# Altium schematic sheet AD-SDIO_2_Click_v101.SchDoc(AD-SDIO_2_Click_v101)
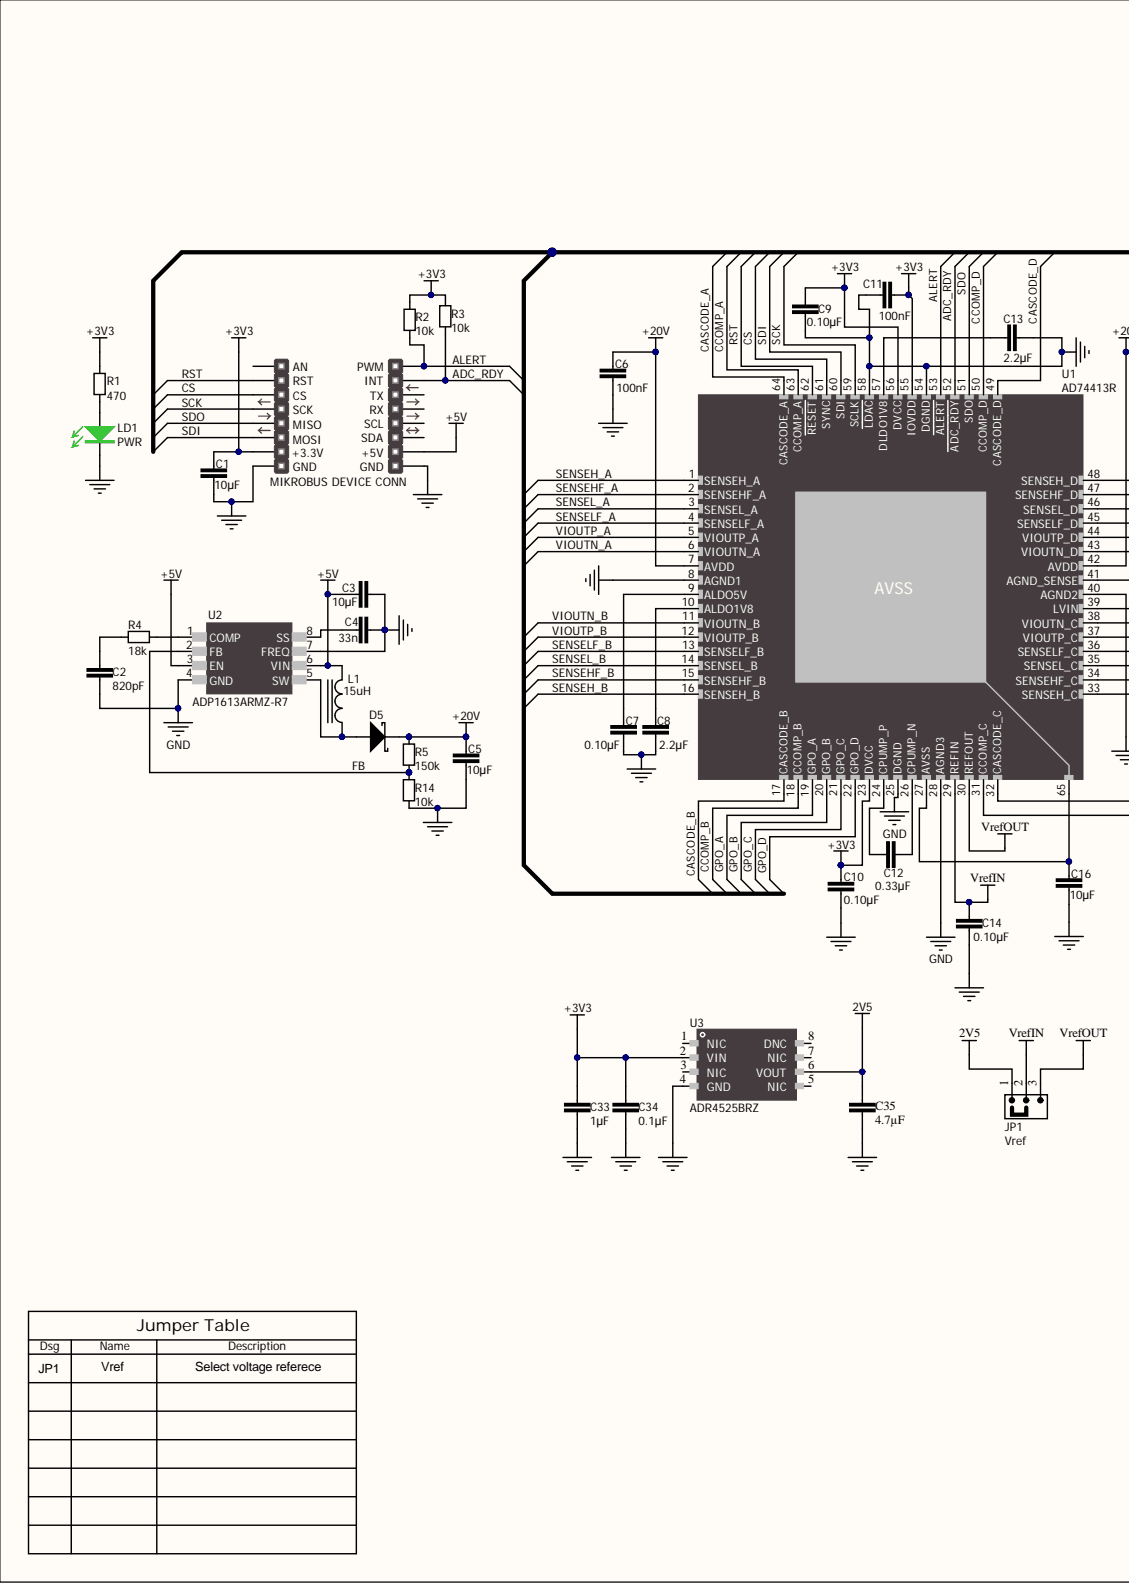
[diagram p0: AD-SDIO_2_Click_v101.SchDoc, left]
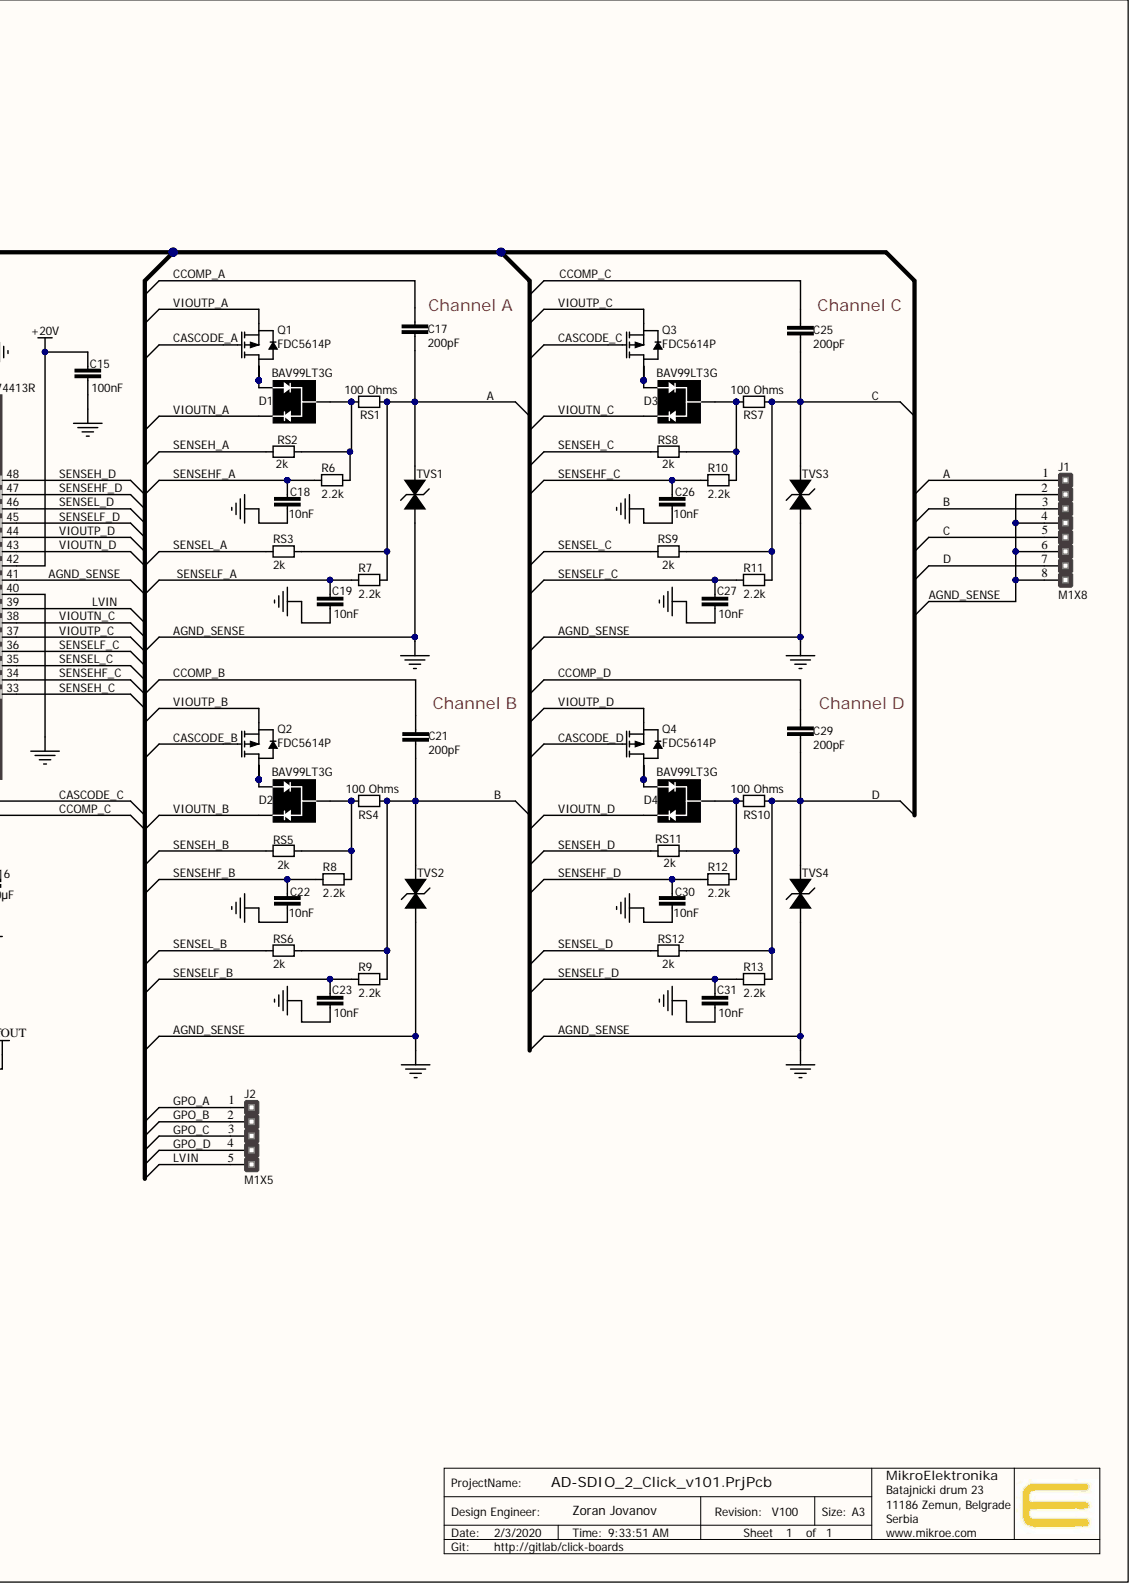
[diagram p1: AD-SDIO_2_Click_v101.SchDoc, right]

## Parts
C1  10µF  [CAPC2013X100N-0805-NoOverlay]
C2  820pF  [CAPC2013X100N-0805-NoOverlay]
C3  10µF  [CAPC2013X100N-0805-NoOverlay]
C4  33n  [CAPC1608X55N-0603-NoOverlay]
C5  10µF  [CAPC2013X100N-0805-NoOverlay]
C6  100nF  [CAPC1608X55N-0603-NoOverlay]
C7  0.10µF  [CAPC1608X55N-0603-NoOverlay]
C8  2.2µF  [CAPC1608X55N-0603-NoOverlay]
C9  0.10µF  [CAPC1608X55N-0603-NoOverlay]
C10  0.10µF  [CAPC1608X55N-0603-NoOverlay]
C11  100nF  [CAPC1608X55N-0603-NoOverlay]
C12  0.33µF  [CAPC2013X100N-0805-NoOverlay]
C13  2.2µF  [CAPC1608X55N-0603-NoOverlay]
C14  0.10µF  [CAPC1608X55N-0603-NoOverlay]
C15  100nF  [CAPC1608X55N-0603-NoOverlay]
C16  10µF  [CAPC2013X100N-0805-NoOverlay]
C17  200pF  [CAPC1608X55N-0603-NoOverlay]
C18  10nF  [CAPC1608X55N-0603-NoOverlay]
C19  10nF  [CAPC1608X55N-0603-NoOverlay]
C21  200pF  [CAPC1608X55N-0603-NoOverlay]
C22  10nF  [CAPC1608X55N-0603-NoOverlay]
C23  10nF  [CAPC1608X55N-0603-NoOverlay]
C25  200pF  [CAPC1608X55N-0603-NoOverlay]
C26  10nF  [CAPC1608X55N-0603-NoOverlay]
C27  10nF  [CAPC1608X55N-0603-NoOverlay]
C29  200pF  [CAPC1608X55N-0603-NoOverlay]
C30  10nF  [CAPC1608X55N-0603-NoOverlay]
C31  10nF  [CAPC1608X55N-0603-NoOverlay]
C33  1µF  [CAPC1005X55N-0402-NoOverlay]
C34  0.1µF  [CAPC1005X55N-0402-NoOverlay]
C35  4.7µF  [CAPC1005X55N-0402-NoOverlay]
D1  BAV99LT3G  [SOT95P240X110-3N-NoOverlay]
D2  BAV99LT3G  [SOT95P240X110-3N-NoOverlay]
D3  BAV99LT3G  [SOT95P240X110-3N-NoOverlay]
D4  BAV99LT3G  [SOT95P240X110-3N-NoOverlay]
D5  MBRA340T3G  [DIODFN430X400X230-2N]  (value not drawn)
J1  M1X8  [HM1x8-NoOverlay]
J2  M1X5  [HM1X5-NoOverlay]
JP1  Vref  [JUMPER-0805-V2-12]
L1  15uH  [XAL4040-NOOVERLAY]
LD1  PWR  [DIOGREENC2013X120N-0805-NoOverlay]
MBD1  MIKROBUS DEVICE CONN  [New Click Template_LARGE-SG]  (no BOM)
Q1  FDC5614P  [TSOT-23-6-NoOverlay]
Q2  FDC5614P  [TSOT-23-6-NoOverlay]
Q3  FDC5614P  [TSOT-23-6-NoOverlay]
Q4  FDC5614P  [TSOT-23-6-NoOverlay]
R1  470  [RESC2013X38N-0805-NOOVERLAY]
R2  10k  [RESC2013X38N-0805-NOOVERLAY]
R3  10k  [RESC2013X38N-0805-NOOVERLAY]
R4  18k  [RESC1508X55N-0603-NOOVERLAY]
R5  150k  [RESC1508X55N-0603-NOOVERLAY]
R6  2.2k  [RESC1508X55N-0603-NOOVERLAY]
R7  2.2k  [RESC1508X55N-0603-NOOVERLAY]
R8  2.2k  [RESC1508X55N-0603-NOOVERLAY]
R9  2.2k  [RESC1508X55N-0603-NOOVERLAY]
R10  2.2k  [RESC1508X55N-0603-NOOVERLAY]
R11  2.2k  [RESC1508X55N-0603-NOOVERLAY]
R12  2.2k  [RESC1508X55N-0603-NOOVERLAY]
R13  2.2k  [RESC1508X55N-0603-NOOVERLAY]
R14  10k  [RESC1508X55N-0603-NOOVERLAY]
RS1  100 Ohms  [RESC1508X55N-0603-NOOVERLAY]
RS2  2k  [RESC1508X55N-0603-NOOVERLAY]
RS3  2k  [RESC1508X55N-0603-NOOVERLAY]
RS4  100 Ohms  [RESC1508X55N-0603-NOOVERLAY]
RS5  2k  [RESC1508X55N-0603-NOOVERLAY]
RS6  2k  [RESC1508X55N-0603-NOOVERLAY]
RS7  100 Ohms  [RESC1508X55N-0603-NOOVERLAY]
RS8  2k  [RESC1508X55N-0603-NOOVERLAY]
RS9  2k  [RESC1508X55N-0603-NOOVERLAY]
RS10  100 Ohms  [RESC1508X55N-0603-NOOVERLAY]
RS11  2k  [RESC1508X55N-0603-NOOVERLAY]
RS12  2k  [RESC1508X55N-0603-NOOVERLAY]
TVS1  SMCJ40CA-TR  [DIOM7959X265N-NoOverlay]  (value not drawn)
TVS2  SMCJ40CA-TR  [DIOM7959X265N-NoOverlay]  (value not drawn)
TVS3  SMCJ40CA-TR  [DIOM7959X265N-NoOverlay]  (value not drawn)
TVS4  SMCJ40CA-TR  [DIOM7959X265N-NoOverlay]  (value not drawn)
U1  AD74413R  [QFN50P900X900X90-65T780X780N-QFN64-NoOverlay]
U2  ADP1613ARMZ-R7  [MSOP8P65_300X490X110L60X31N - NoOverlay]
U3  ADR4525BRZ  [SOIC127P600X175-8N-NoOverlay]

## Nets
2V5: C35.2 JP1.1 U3.6(VOUT)
+3V3: C1.2 C9.1 C10.1 C11.1 C33.2 C34.2 MBD1.7(+3.3V) R1.2 R2.2 R3.2 U1.23(DVCC) U1.55(IOVDD) U1.56(DVCC) U3.2(VIN)
+5V: C3.1 L1.1 MBD1.10(+5V) U2.3(EN) U2.6(VIN)
+20V: C5.2 C6.2 C15.2 D5.1 R5.2 U1.7(AVDD) U1.42(AVDD)
VrefIN: C14.2 JP1.2 U1.29(REFIN)
VrefOUT: JP1.3 U1.30(REFOUT)
A: C17.1 J1.1 R7.1 RS1.2 RS3.2 TVS1.2
ADC_RDY: MBD1.15(INT) R3.1 U1.52(ADC_RDY)
AGND_SENSE: C1.1 C2.1 C3.2 C4.2 C5.1 C6.1 C7.1 C8.1 C9.2 C10.2 C11.2 C13.2 C14.1 C15.1 C16.1 C18.1 C19.1 C22.1 C23.1 C26.1 C27.1 C30.1 C31.1 C33.1 C34.1 C35.1 J1.2 J1.4 J1.6 J1.8 LD1.1 MBD1.8(GND) MBD1.9(GND) R14.1 TVS1.1 TVS2.1 TVS3.1 TVS4.1 U1.8(AGND1) U1.25(DGND) U1.28(AGND3) U1.40(AGND2) U1.41(AGND_SENSE) U1.54(DGND) U1.58(LDAC) U2.4(GND) U2.7(FREQ) U3.4(GND)
ALERT: MBD1.16(PWM) R2.1 U1.53(ALERT)
B: C21.1 J1.3 R9.1 RS4.2 RS6.2 TVS2.2
C: C25.1 J1.5 R11.1 RS7.2 RS9.2 TVS3.2
CASCODE_A: Q1.3 U1.64(CASCODE_A)
CASCODE_B: Q2.3 U1.17(CASCODE_B)
CASCODE_C: Q3.3 U1.32(CASCODE_C)
CASCODE_D: Q4.3 U1.49(CASCODE_D)
CCOMP_A: C17.2 U1.63(CCOMP_A)
CCOMP_B: C21.2 U1.18(CCOMP_B)
CCOMP_C: C25.2 U1.31(CCOMP_C)
CCOMP_D: C29.2 U1.50(CCOMP_D)
CS: MBD1.3(CS) U1.61(SYNC)
D: C29.1 J1.7 R13.1 RS10.2 RS12.2 TVS4.2
FB: R5.1 R14.2 U2.2(FB)
GPO_A: J2.1 U1.19(GPO_A)
GPO_B: J2.2 U1.20(GPO_B)
GPO_C: J2.3 U1.21(GPO_C)
GPO_D: J2.4 U1.22(GPO_D)
LVIN: J2.5 U1.39(LVIN)
RST: MBD1.2(RST) U1.62(RESET)
SCK: MBD1.4(SCK) U1.59(SCLK)
SDI: MBD1.6(MOSI) U1.60(SDI)
SDO: MBD1.5(MISO) U1.51(SDO)
SENSEH_A: RS2.1 U1.1(SENSEH_A)
SENSEH_B: RS5.1 U1.16(SENSEH_B)
SENSEH_C: RS8.1 U1.33(SENSEH_C)
SENSEH_D: RS11.1 U1.48(SENSEH_D)
SENSEHF_A: C18.2 R6.2 U1.2(SENSEHF_A)
SENSEHF_B: C22.2 R8.2 U1.15(SENSEHF_B)
SENSEHF_C: C26.2 R10.2 U1.34(SENSEHF_C)
SENSEHF_D: C30.2 R12.2 U1.47(SENSEHF_D)
SENSEL_A: RS3.1 U1.3(SENSEL_A)
SENSEL_B: RS6.1 U1.14(SENSEL_B)
SENSEL_C: RS9.1 U1.35(SENSEL_C)
SENSEL_D: RS12.1 U1.46(SENSEL_D)
SENSELF_A: C19.2 R7.2 U1.4(SENSELF_A)
SENSELF_B: C23.2 R9.2 U1.13(SENSELF_B)
SENSELF_C: C27.2 R11.2 U1.36(SENSELF_C)
SENSELF_D: C31.2 R13.2 U1.45(SENSELF_D)
VIOUTN_A: D1.2 U1.6(VIOUTN_A)
VIOUTN_B: D2.2 U1.11(VIOUTN_B)
VIOUTN_C: D3.2 U1.38(VIOUTN_C)
VIOUTN_D: D4.2 U1.43(VIOUTN_D)
VIOUTP_A: Q1.4 U1.5(VIOUTP_A)
VIOUTP_B: Q2.4 U1.12(VIOUTP_B)
VIOUTP_C: Q3.4 U1.37(VIOUTP_C)
VIOUTP_D: Q4.4 U1.44(VIOUTP_D)
NetC2_2: C2.2 R4.1
NetC4_1: C4.1 U2.8(SS)
NetC7_2: C7.2 U1.9(ALDO5V)
NetC8_2: C8.2 U1.10(ALDO1V8)
NetC12_1: C12.1 U1.24(CPUMP_P)
NetC12_2: C12.2 U1.26(CPUMP_N)
NetC13_1: C13.1 U1.57(DLDO1V8)
NetC16_2: C16.2 U1.27(AVSS) U1.65
NetD1_1: D1.1 Q1.1 Q1.2 Q1.5 Q1.6
NetD1_3: D1.3 R6.1 RS1.1 RS2.2
NetD2_1: D2.1 Q2.1 Q2.2 Q2.5 Q2.6
NetD2_3: D2.3 R8.1 RS4.1 RS5.2
NetD3_1: D3.1 Q3.1 Q3.2 Q3.5 Q3.6
NetD3_3: D3.3 R10.1 RS7.1 RS8.2
NetD4_1: D4.1 Q4.1 Q4.2 Q4.5 Q4.6
NetD4_3: D4.3 R12.1 RS10.1 RS11.2
NetD5_2: D5.2 L1.2 U2.5(SW)
NetLD1_2: LD1.2 R1.1
NetR4_2: R4.2 U2.1(COMP)
N00056:

Not connected: MBD1 1(AN) 11(SDA) 12(SCL) 13(RX) 14(TX); U3 1(NIC) 3(NIC) 5(NIC) 7(NIC) 8(DNC)

## Library data of the parts
C1 C3 C5 C16 = 0100000012963: CAP CER 10UF 25V X5R 0805; Manufacturer Part Number: GRM21BR61E106KA73L; Manufacturer: Murata Electronics North America; Tolerance: ±10%; item_id: 1714; id: 376; Database Table Name: capacitors; Assembly: SMD; Voltage Rated: 25V; Temperature Coefficient: X5R; Part Type: Capacitors; Package Case: 0805 (2012 Metric); Library Name: ME-Altium Lib.SVNDbLib; Family: CERAMIC CAPACITOR; Datasheet URL: http://www.murata.com/~/media/webrenewal/support/library/catalog/products/capacitor/mlcc/c02e.ashx; Component Kind: Standard; Category: Capacitors; Capacitance: 10µF; Barcode: 0100000012963
C2 = 3500000174994: CAP CER 820PF 50V X7R 0805; Manufacturer Part Number: CL21B821KBANNNC; Manufacturer: Samsung Electro-Mechanics; Tolerance: ±10%; item_id: 15634; id: 609; Database Table Name: capacitors; Assembly: SMD; Voltage Rated: 50V; Temperature Coefficient: X7R; Part Type: Capacitors; Package Case: 0805 (2012 Metric); Library Name: ME-Altium Lib.SVNDbLib; Family: CERAMIC CAPACITOR; Datasheet URL: http://www.samsungsem.com/kr/support/product-search/mlcc/CL21B821KBANNNC.jsp; Component Kind: Standard; Category: Capacitors; Capacitance: 820pF; Barcode: 3500000174994
C4 = 2911010029463: Manufacturer Part Number: CC0603KRX7R9BB333; Manufacturer: Yageo; Voltage Rated: 50; Tolerance: 10%; Temperature Coefficient: X7R; Recommended Rating: Silver; item_id: 3855; id: 142; Database Table Name: capacitors; Assembly: SMD; Part Type: Capacitors; Package Case: 0603; Library Name: ME-Altium Lib.SVNDbLib; Family: CERAMIC CAPACITOR; Component Kind: Standard; Category: Capacitors; Capacitance: 33n; Barcode: 2911010029463
C6 C7 C9-C11 C14 C15 = 0100000012857: CAP CER 0.1UF 50V X7R 0603; Manufacturer Part Number: CL10B104KB8NNNC; Manufacturer: Samsung Electro-Mechanics America, Inc.; Tolerance: ±10%; item_id: 1703; id: 275; Database Table Name: capacitors; Assembly: SMD; Voltage Rated: 50V; Temperature Coefficient: X7R; Part Type: Capacitors; Package Case: 0603 (1608 Metric); Library Name: ME-Altium Lib.SVNDbLib; Family: CERAMIC CAPACITOR; Datasheet URL: http://www.samsungsem.com/kr/support/product-search/mlcc/CL10B104KB8NNNC.jsp; Component Kind: Standard; Category: Capacitors; Barcode: 0100000012857
  C6 C11 C15: Capacitance: 100nF
  C7 C9 C10 C14: Capacitance: 0.10µF
C8 C13 = 2911010021870: CAP CER 2.2UF 6.3V X5R 0603; Manufacturer Part Number: JMK107BJ225KA-T; Manufacturer: Taiyo Yuden; Tolerance: ±10%; Recommended Rating: Gold; item_id: 3096; id: 64; Database Table Name: capacitors; Assembly: SMD; Voltage Rated: 6.3V; Temperature Coefficient: X5R; Part Type: Capacitors; Package Case: 0603 (1608 Metric); Library Name: ME-Altium Lib.SVNDbLib; Family: CERAMIC CAPACITOR; Datasheet URL: http://ds.yuden.co.jp/TYCOMPAS/ut/detail.do?productNo=JMK107BJ225KA-T&fileName=JMK107BJ225KA-T_SS&mode=specSheetDownload; Component Kind: Standard; Category: Capacitors; Capacitance: 2.2µF; Barcode: 2911010021870
C12 = 2911010048525: CAP CER 0.33UF 50V X7R 0805; Manufacturer Part Number: CL21B334KBFNNNE; Manufacturer: Samsung Electro-Mechanics America, Inc.; Tolerance: ±10%; item_id: 5533; id: 109; Database Table Name: capacitors; Assembly: SMD; Voltage Rated: 50V; Temperature Coefficient: X7R; Part Type: Capacitors; Package Case: 0805 (2012 Metric); Library Name: ME-Altium Lib.SVNDbLib; Family: CERAMIC CAPACITOR; Datasheet URL: http://www.samsungsem.com/kr/support/product-search/mlcc/CL21B334KBFNNNE.jsp; Component Kind: Standard; Category: Capacitors; Capacitance: 0.33µF; Barcode: 2911010048525
C17 C21 C25 C29 = 3500000242198: CAP CER 200PF 50V C0G/NP0 0603; Manufacturer Part Number: CL10C201JB8NNNC; Manufacturer: Samsung Electro-Mechanics; Tolerance: ±5%; Temperature Coefficient: C0G, NP0; item_id: 22359; id: 957; Database Table Name: capacitors; Assembly: SMD; Voltage Rated: 50V; Part Type: Capacitors; Package Case: 0603 (1608 Metric); Library Name: ME-Altium Lib.SVNDbLib; Family: CERAMIC CAPACITOR; Datasheet URL: http://www.samsungsem.com/kr/support/product-search/mlcc/CL10C201JB8NNNC.jsp; Component Kind: Standard; Category: Capacitors; Capacitance: 200pF; Barcode: 3500000242198
C18 C19 C22 C23 C26 C27 C30 C31 = 0100000013687: CAP CER 10000PF 50V X7R 0603; Manufacturer Part Number: CL10B103KB8NNNC; Manufacturer: Samsung Electro-Mechanics; Tolerance: ±10%; Recommended Rating: Gold; item_id: 1786; id: 77; Database Table Name: capacitors; Assembly: SMD; Voltage Rated: 50V; Temperature Coefficient: X7R; Part Type: Capacitors; Package Case: 0603 (1608 Metric); Library Name: ME-Altium Lib.SVNDbLib; Family: CERAMIC CAPACITOR; Datasheet URL: http://www.samsungsem.com/kr/support/product-search/mlcc/CL10B103KB8NNNC.jsp; Component Kind: Standard; Category: Capacitors; Capacitance: 10nF; Barcode: 0100000013687
C33 = 2911010025762: CAP CER 1UF 10V X5R 0402; Manufacturer Part Number: LMK105BJ105KV-F; Manufacturer: Taiyo Yuden; Tolerance: ±10%; item_id: 3485; id: 62; Database Table Name: capacitors; Assembly: SMD; Voltage Rated: 10V; Temperature Coefficient: X5R; Part Type: Capacitors; Package Case: 0402 (1005 Metric); Library Name: ME-Altium Lib.SVNDbLib; Family: CERAMIC CAPACITOR; Datasheet URL: http://ds.yuden.co.jp/TYCOMPAS/ut/detail.do?productNo=LMK105BJ105KV-F&fileName=LMK105BJ105KV-F_SS&mode=specSheetDownload; Component Kind: Standard; Category: Capacitors; Capacitance: 1µF; Barcode: 2911010025762
C34 = 2911010025755: CAP CER 0.1UF 10V X5R 0402; Manufacturer Part Number: LMK105BJ104KV-F; Manufacturer: Taiyo Yuden; Tolerance: ±10%; Recommended Rating: Platinum; item_id: 3484; id: 63; Database Table Name: capacitors; Assembly: SMD; Voltage Rated: 10V; Temperature Coefficient: X5R; Part Type: Capacitors; Package Case: 0402 (1005 Metric); Library Name: ME-Altium Lib.SVNDbLib; Family: CERAMIC CAPACITOR; Datasheet URL: http://ds.yuden.co.jp/TYCOMPAS/ut/detail.do?productNo=LMK105BJ104KV-F&fileName=LMK105BJ104KV-F_SS&mode=specSheetDownload; Component Kind: Standard; Category: Capacitors; Capacitance: 0.1µF; Barcode: 2911010025755
C35 = 3500000169549: CAP CER 4.7UF 10V X5R 0402; Manufacturer Part Number: CL05A475KP5NRNC; Manufacturer: Samsung Electro-Mechanics; Tolerance: ±10%; item_id: 15089; id: 579; Database Table Name: capacitors; Assembly: SMD; Voltage Rated: 10V; Temperature Coefficient: X5R; Part Type: Capacitors; Package Case: 0402 (1005 Metric); Library Name: ME-Altium Lib.SVNDbLib; Family: CERAMIC CAPACITOR; Datasheet URL: http://media.digikey.com/pdf/Data%20Sheets/Samsung%20PDFs/CL_Series_MLCC_ds.pdf; Component Kind: Standard; Category: Capacitors; Capacitance: 4.7µF; Barcode: 3500000169549
D1-D4 = 0100000101797: DIODE ARRAY GP 100V 215MA SOT23; Manufacturer: ON Semiconductor; Voltage  Forward: 1.25V @ 150mA; Speed: Fast Recovery =< 500ns, > 200mA (Io); item_id: 9293; id: 249; Diode Type: Standard; Database Table Name: discrete_semiconductors; Current Average Rectified Io per Diode: 215mA (DC); Assembly: SMD; Voltage  DC Reverse: 100V; Part Type: Discrete Semiconductors; Package Case: TO-236-3, SC-59, SOT-23-3; Manufacturer Part Number: BAV99LT3G; Library Name: ME-Altium Lib.SVNDbLib; Family: DIODE ARRAY; Datasheet URL: http://www.onsemi.com/pub_link/Collateral/BAV99LT1-D.PDF; Component Kind: Standard; Category: Discrete Semiconductor Products; Barcode: 0100000101797
D5 = 3500000184344: DIODE SCHOTTKY 40V 3A SMA; Manufacturer: ON Semiconductor; Speed: Fast Recovery =< 500ns, > 200mA (Io); item_id: 16571; id: 409; Database Table Name: discrete_semiconductors; Assembly: SMD; Voltage  DC Reverse: 40V; Part Type: Discrete Semiconductors; Package Case: DO-214AC, SMA; Manufacturer Part Number: MBRA340T3G; Library Name: ME-Altium Lib.SVNDbLib; Family: DIODE; Diode Type: Schottky; Datasheet URL: http://www.onsemi.com/pub/Collateral/MBRA340T3-D.PDF; Current  Average Rectified: 3A; Component Kind: Standard; Category: Discrete Semiconductor Products; Barcode: 3500000184344
J1 = 0100000004081: CONN HEADER 8 POS 2.54; Manufacturer Part Number: 61300811121; Manufacturer: Wurth Electronics Inc; Pitch: 0.100" (2.54mm); Number of Positions: 8; item_id: 679; id: 151; Database Table Name: connectors_electromechanical; Connector Type: Header, Unshrouded; Assembly: TH; Part Type: Connectors, Electromechanical; Number of Rows: 1; Mounting Type: Through Hole; Library Name: ME-Altium Lib.SVNDbLib; Features: -; Family: HEADER MALE; Datasheet URL: http://katalog.we-online.de/em/datasheet/6130xx11121.pdf; Contact Type: Male Pin; Contact Finish: Gold; Component Kind: Standard; Color: Black; Category: Connectors, Interconnects; Barcode: 0100000004081
J2 = 0100000004289: Manufacturer Part Number: 1X5 Male Pin (3mm leg, 6mm pin); Manufacturer: ELECSOUND ELECTRONIC; Pitch: 2.54mm; Number of Positions: 5; item_id: 700; id: 248; Database Table Name: connectors_electromechanical; Connector Type: Header; Assembly: TH; Part Type: Connectors, Electromechanical; Number of Rows: 1; Mounting Type: TH; Library Name: ME-Altium Lib.SVNDbLib; Family: HEADER MALE; Component Kind: Standard; Barcode: 0100000004289
JP1 = SEL JMP 0805 SMD: Manufacturer Part Number: RC0805JR-070RL; Manufacturer: Yageo; Tolerance: Jumper; Resistance: 0.0; Power: 0.125W, 1/8W; Barcode: 0100000003947; Assembly: SMD; Temperature Coefficient: -; Part Type: Resistors; Package Case: 0805 (2012 Metric); Library Name: ME-Integrated_Library.IntLib; Datasheet URL: http://www.yageo.com/NewPortal/yageodocoutput?fileName=/pdf/R-Chip/PYu-RC_Group_51_RoHS_L_3.pdf; Component Kind: Standard; Category: Resistors
L1 = 2911010049133: FIXED IND 15uH 2.8A; Manufacturer Part Number: XAL4040-153MEB; Manufacturer: Coilcraft; Value: 15u; Tolerance: 20%; item_id: 5594; id: 6; DC Resistance: 120mOhm; Database Table Name: inductor_transf_filter_ferrite; Assembly: SMD; Part Type: Inductor, Transf, Filter, Ferrite; Package Case: 4.3x4.3mm; Library Name: ME-Altium Lib.SVNDbLib; Inductance: 15uH; Family: INDUCTOR; Datasheet URL: http://www.mouser.com/ds/2/597/xal4000-270751.pdf; Current Rating: 2.8A; Component Kind: Standard; Category: Inductors, Coils, Chokes; Barcode: 2911010049133
LD1 = 2911010024338: LED GREEN CLEAR 0805 SMD; Manufacturer Part Number: APT2012SGC; Manufacturer: Kingbright; Wavelength: 568nm; Voltage Forward: 2.2V; Recommended Rating: Platinum; Lens Color: Colorless; item_id: 3342; id: 28; Database Table Name: optoelectronics; Part Type: Optoelectronics; Package Case: 0805 (2012 Metric); Library Name: ME-Altium Lib.SVNDbLib; Lens Transparency: Clear; Family: LED; Datasheet URL: http://www.kingbrightusa.com/images/catalog/SPEC/APT2012SGC.pdf; Component Kind: Standard; Color: Green; Category: Optoelectronics; Barcode: 2911010024338; Assembly: SMD
MBD1 = MIKROBUS DEVICE CONN: mikroBUS Target Header; Library Name: ME-Integrated_Library.IntLib
Q1-Q4 = 0100000013014: MOSFET P-CH 60V 3A SSOT-6; Manufacturer: Fairchild Semiconductor; Vgs: 3V @ 250µA; Rds On max: 105 mOhm @ 3A, 10V; Power Max: 800mW; item_id: 1719; Input Capacitance: 759pF @ 30V; id: 61; FET Type: MOSFET P-Channel, Metal Oxide; Database Table Name: discrete_semiconductors; Current  Continuous Drain: 3A (Ta); Assembly: SMD; Part Type: Discrete Semiconductors; Package Case: SOT-23-6 Thin, TSOT-23-6; Manufacturer Part Number: FDC5614P; Library Name: ME-Altium Lib.SVNDbLib; Family: FET; Drain to Source Voltage: 60V; Datasheet URL: http://www.fairchildsemi.com/datasheets/FD/FDC5614P.pdf; Component Kind: Standard; Category: Discrete Semiconductor Products; Barcode: 0100000013014
R1 = 0100000007921: RES SMD 470 OHM 1% 1/8W 0805; Manufacturer Part Number: RC0805FR-07470RL; Manufacturer: Yageo; Temperature Coefficient: ±100ppm/°C; Recommended Rating: Platinum; Power: 0.125W, 1/8W; item_id: 1082; id: 207; Database Table Name: resistors; Tolerance: ±1%; Resistance: 470; Part Type: Resistors; Package Case: 0805 (2012 Metric); Library Name: ME-Altium Lib.SVNDbLib; Family: RESISTOR; Datasheet URL: http://www.yageo.com/NewPortal/yageodocoutput?fileName=/pdf/R-Chip/PYu-RC_Group_51_RoHS_L_3.pdf; Component Kind: Standard; Category: Resistors; Barcode: 0100000007921; Assembly: SMD
R2 R3 = 0100000001974: RES SMD 10K OHM 1% 1/8W 0805; Manufacturer Part Number: RC0805FR-0710KL; Manufacturer: Yageo; Temperature Coefficient: ±100ppm/°C; Recommended Rating: Platinum; Power: 0.125W, 1/8W; item_id: 462; id: 228; Database Table Name: resistors; Tolerance: ±1%; Resistance: 10k; Part Type: Resistors; Package Case: 0805 (2012 Metric); Library Name: ME-Altium Lib.SVNDbLib; Family: RESISTOR; Datasheet URL: http://www.yageo.com/NewPortal/yageodocoutput?fileName=/pdf/R-Chip/PYu-RC_Group_51_RoHS_L_3.pdf; Component Kind: Standard; Category: Resistors; Barcode: 0100000001974; Assembly: SMD
R4 = 0100000084731: RES SMD 18K OHM 1% 1/10W 0603; Manufacturer Part Number: RC0603FR-0718KL; Manufacturer: Yageo; Temperature Coefficient: ±100ppm/°C; Power: 0.1W, 1/10W; item_id: 7588; id: 264; Database Table Name: resistors; Tolerance: ±1%; Resistance: 18k; Part Type: Resistors; Package Case: 0603 (1608 Metric); Library Name: ME-Altium Lib.SVNDbLib; Family: RESISTOR; Datasheet URL: http://www.yageo.com/NewPortal/yageodocoutput?fileName=/pdf/R-Chip/PYu-RC_Group_51_RoHS_L_3.pdf; Component Kind: Standard; Category: Resistors; Barcode: 0100000084731; Assembly: SMD
R5 = 2911010028589: RES SMD 150K OHM 1% 1/10W 0603; Manufacturer Part Number: RC0603FR-07150KL; Manufacturer: Yageo; Temperature Coefficient: ±100ppm/°C; Recommended Rating: Silver; Power: 0.1W, 1/10W; item_id: 3767; id: 87; Database Table Name: resistors; Tolerance: ±1%; Resistance: 150k; Part Type: Resistors; Package Case: 0603 (1608 Metric); Library Name: ME-Altium Lib.SVNDbLib; Family: RESISTOR; Datasheet URL: http://www.yageo.com/NewPortal/yageodocoutput?fileName=/pdf/R-Chip/PYu-RC_Group_51_RoHS_L_3.pdf; Component Kind: Standard; Category: Resistors; Barcode: 2911010028589; Assembly: SMD
R6-R13 = 2911010021863: RES SMD 2.2K OHM 1% 1/10W 0603; Manufacturer Part Number: RC0603FR-072K2L; Manufacturer: Yageo; Temperature Coefficient: ±100ppm/°C; Recommended Rating: Gold; Power: 0.1W, 1/10W; item_id: 3095; id: 105; Database Table Name: resistors; Tolerance: ±1%; Resistance: 2.2k; Part Type: Resistors; Package Case: 0603 (1608 Metric); Library Name: ME-Altium Lib.SVNDbLib; Family: RESISTOR; Datasheet URL: http://www.yageo.com/NewPortal/yageodocoutput?fileName=/pdf/R-Chip/PYu-RC_Group_51_RoHS_L_3.pdf; Component Kind: Standard; Category: Resistors; Barcode: 2911010021863; Assembly: SMD
R14 = 0100000013427: RES SMD 10K OHM 1% 1/10W 0603; Manufacturer Part Number: RC0603FR-0710KL; Manufacturer: Yageo; Temperature Coefficient: ±100ppm/°C; Recommended Rating: Platinum; Power: 0.1W, 1/10W; item_id: 1760; id: 193; Database Table Name: resistors; Tolerance: ±1%; Resistance: 10k; Part Type: Resistors; Package Case: 0603 (1608 Metric); Library Name: ME-Altium Lib.SVNDbLib; Family: RESISTOR; Datasheet URL: http://www.yageo.com/NewPortal/yageodocoutput?fileName=/pdf/R-Chip/PYu-RC_Group_51_RoHS_L_3.pdf; Component Kind: Standard; Category: Resistors; Barcode: 0100000013427; Assembly: SMD
RS1 RS4 RS7 RS10 = 3500000228468: RES 100 OHM 0.1% 1/8W 0603; Manufacturer Part Number: TNPW0603100RBYEN; Manufacturer: Vishay Dale; Temperature Coefficient: ±10ppm/°C; Power: 0.125W, 1/8W; item_id: 20984; id: 1189; Database Table Name: resistors; Assembly: SMD; Tolerance: ±0.1%; Resistance: 100 Ohms; Part Type: Resistors; Package Case: 0603 (1608 Metric); Library Name: ME-Altium Lib.SVNDbLib; Family: RESISTOR; Datasheet URL: http://www.vishay.com/docs/28758/tnpw_e3.pdf; Component Kind: Standard; Category: Resistors; Barcode: 3500000228468
RS2 RS5 RS8 RS11 = 3500000228482: RES SMD 2K OHM 0.1% 1/10W 0603; Manufacturer Part Number: ERA-3ARB202V; Manufacturer: Panasonic Electronic Components; Temperature Coefficient: ±10ppm/°C; Power: 0.1W, 1/10W; item_id: 20986; id: 1190; Database Table Name: resistors; Tolerance: ±0.1%; Resistance: 2k; Part Type: Resistors; Package Case: 0603 (1608 Metric); Library Name: ME-Altium Lib.SVNDbLib; Family: RESISTOR; Datasheet URL: https://industrial.panasonic.com/cdbs/www-data/pdf/RDM0000/AOA0000C307.pdf; Component Kind: Standard; Category: Resistors; Barcode: 3500000228482; Assembly: SMD
RS3 RS6 RS9 RS12 = 2911010043148: RES SMD 2K OHM 1% 1/10W 0603; Manufacturer Part Number: RC0603FR-072KL; Manufacturer: Yageo; Temperature Coefficient: ±100ppm/°C; Power: 0.1W, 1/10W; item_id: 4994; id: 39; Database Table Name: resistors; Tolerance: ±1%; Resistance: 2k; Part Type: Resistors; Package Case: 0603 (1608 Metric); Library Name: ME-Altium Lib.SVNDbLib; Family: RESISTOR; Datasheet URL: http://www.yageo.com/NewPortal/yageodocoutput?fileName=/pdf/R-Chip/PYu-RC_Group_51_RoHS_L_3.pdf; Component Kind: Standard; Category: Resistors; Barcode: 2911010043148; Assembly: SMD
TVS1-TVS4 = 3500000225320: Manufacturer: STMicroelectronics; Voltage  Reverse Standoff: 40V; Voltage Clamping Max Ipp: 84V; Voltage  Breakdown: 44.4V; item_id: 20670; id: 501; Database Table Name: discrete_semiconductors; Current Peak Pulse: 119A (8/20µs); Assembly: SMD; Part Type: Discrete Semiconductors; Package Case: DO-214AB, SMC; Manufacturer Part Number: SMCJ40CA-TR; Library Name: ME-Altium Lib.SVNDbLib; Family: TVS DIODE; Datasheet URL: https://www.st.com/content/ccc/resource/technical/document/datasheet/ed/51/0b/1f/07/5e/42/1e/CD00001367.pdf/files/CD00001367.pdf/jcr:content/translations/en.CD00001367.pdf; Component Kind: Standard; Category: Circuit Protection; Barcode: 3500000225320
U1 = 3500000225009: Manufacturer: Analog Devices Inc.; item_id: 20638; id: 2551; Data Interface: SPI; Database Table Name: integrated_circuits; Assembly: SMD; Type: ADC, DAC; Part Type: Integrated Circuits; Package Case: LFCSP-64; Manufacturer Part Number: AD74413R; Library Name: ME-Altium Lib.SVNDbLib; Family: ADCs/DACs - Special Purpose; Component Kind: Standard; Category: Integrated Circuits (ICs); Barcode: 3500000225009
U2 = 3500000227393: Manufacturer: Analog Devices Inc.; Voltage  Input Min: 2.5V; Voltage  Input Max: 5.5V; Topology: Boost, SEPIC; Output Type: Adjustable; Output Configuration: Positive; Number of Outputs: 1; item_id: 20877; id: 2576; Function: Step-Up, Step-Up/Step-Down; Database Table Name: integrated_circuits; Current  Output: 2A (Switch); Assembly: SMD; Part Type: Integrated Circuits; Package Case: 8-TSSOP, 8-MSOP (0.118", 3.00mm Width); Manufacturer Part Number: ADP1613ARMZ-R7; Library Name: ME-Altium Lib.SVNDbLib; Family: Voltage Regulators - DC DC Switching Regulators; Datasheet URL: https://www.analog.com/media/en/technical-documentation/data-sheets/ADP1612_1613.pdf; Component Kind: Standard; Barcode: 3500000227393
U3 = 3500000242341: IC VREF SERIES 2.5V 8SOIC; Database Table Name: integrated_circuits; Manufacturer Part Number: ADR4525BRZ; Library Name: ME-Altium Lib.SVNDbLib; Component Kind: Standard; Barcode: 3500000242341
